# Altium schematic sheet V2.4分线板.SchDoc
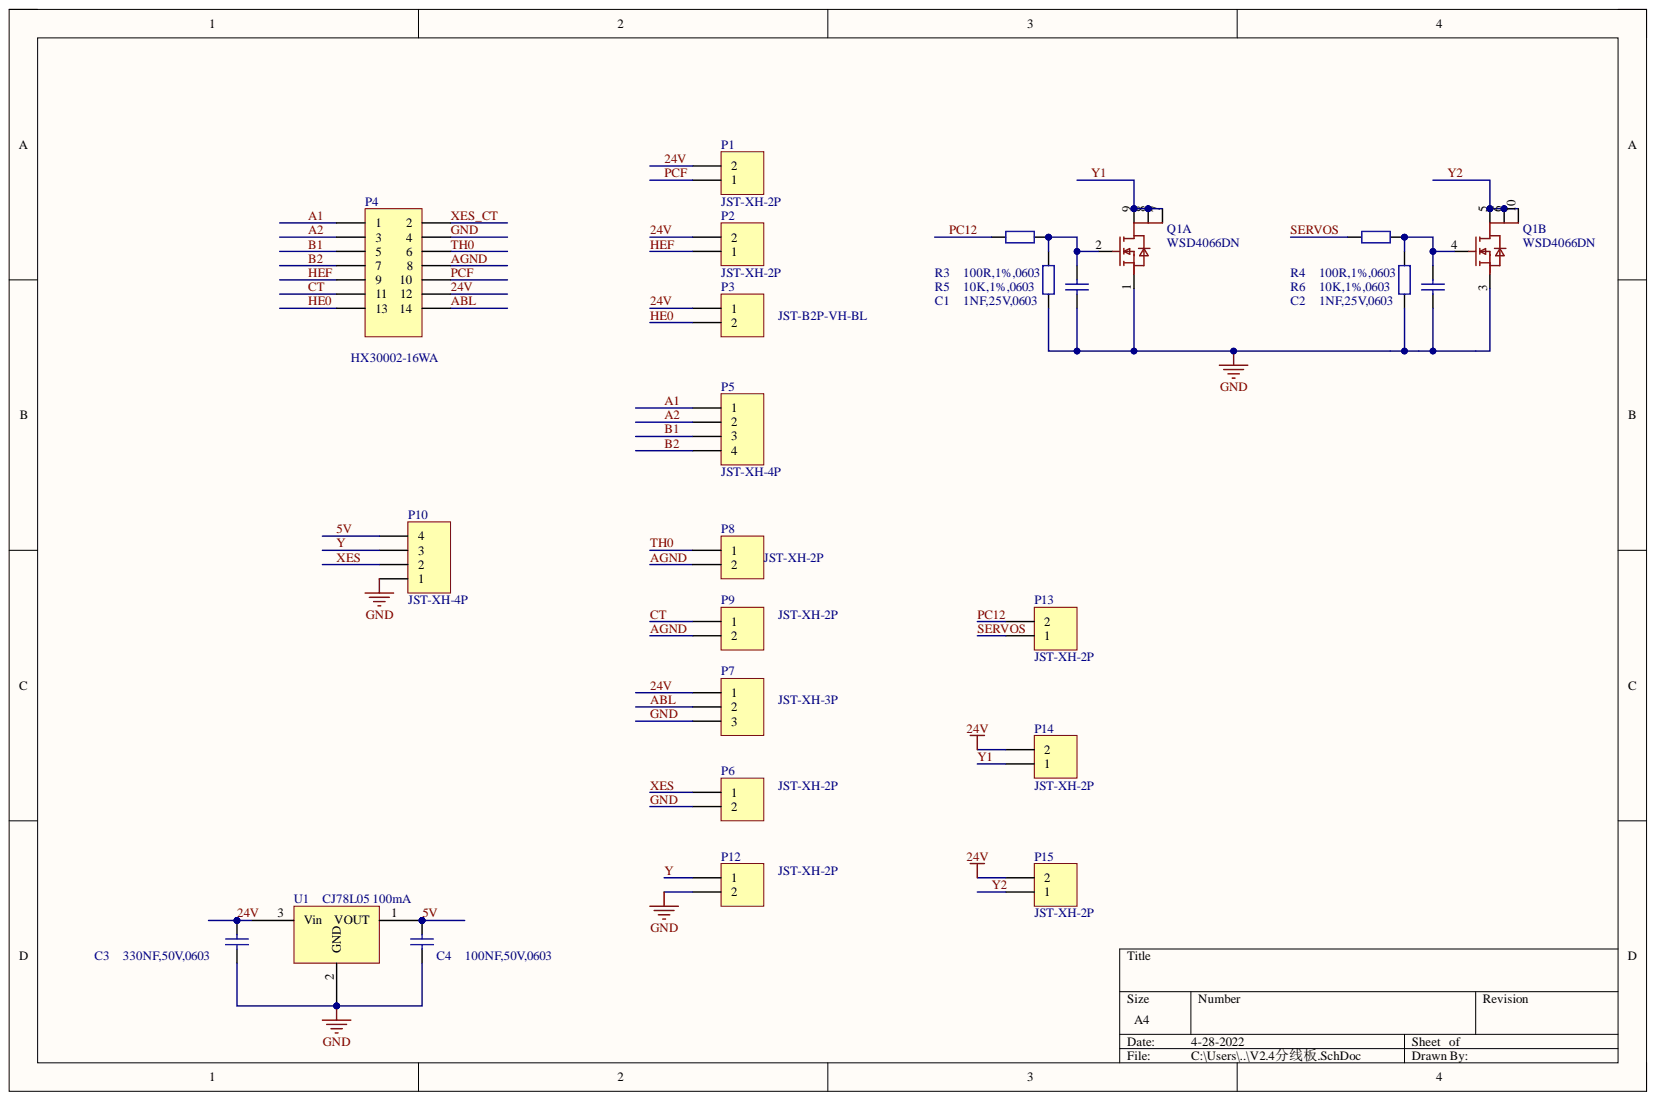
[diagram p0: V2.4分线板.SchDoc]

## Parts
C1  1NF,25V,0603  [0603_C]
C2  1NF,25V,0603  [0603_C]
C3  330NF,50V,0603  [0603_C]
C4  100NF,50V,0603  [0603_C]
P1  JST-XH-2P  [2.5mm-2p-JST]
P2  JST-XH-2P  [2.5mm-2p-JST]
P3  JST-B2P-VH-BL  [3.0-2P]
P4  HX30002-16WA  [3.0-2*7P]
P5  JST-XH-4P  [2.5mm-4p-JST]
P6  JST-XH-2P  [2.5mm-2p-JST]
P7  JST-XH-3P  [2.5mm-3p-JST]
P8  JST-XH-2P  [2.5mm-2p-JST]
P9  JST-XH-2P  [2.5mm-2p-JST]
P10  JST-XH-4P  [2.5mm-4p-JST]
P12  JST-XH-2P  [2.5mm-2p-JST]
P13  JST-XH-2P  [2.5mm-2p-JST]
P14  JST-XH-2P  [2.5mm-2p-JST]
P15  JST-XH-2P  [2.5mm-2p-JST]
Q1  WSD4066DN  [DFN-3X3-8]  (parts A,B)
R3  100R,1%,0603  [0603_R]
R4  100R,1%,0603  [0603_R]
R5  10K,1%,0603  [0603_R]
R6  10K,1%,0603  [0603_R]
U1  CJ78L05 100mA  [SOT-89(SOT-89-3)]

## Nets
5V: C4.2 P10.4 U1.1
24V: C3.2 P1.2 P2.2 P3.1 P4.12 P7.1 P14.2 P15.2 U1.3
A1: P4.1 P5.1
A2: P4.3 P5.2
ABL: P4.14 P7.2
AGND: P4.8 P8.2 P9.2
B1: P4.5 P5.3
B2: P4.7 P5.4
CT: P4.11 P9.1
GND: C1.1 C2.1 C3.1 C4.1 P4.4 P6.2 P7.3 P10.1 P12.2 Q1.1 Q1.3 R5.1 R6.1 U1.2
HE0: P3.2 P4.13
HEF: P2.1 P4.9
PC12: P13.2 R3.1
PCF: P1.1 P4.10
SERVOS: P13.1 R4.1
TH0: P4.6 P8.1
XES: P6.1 P10.2
XES_CT: P4.2
Y: P10.3 P12.1
Y1: P14.1 Q1.7 Q1.8 Q1.9
Y2: P15.1 Q1.5 Q1.6 Q1.10
NetC1_2: C1.2 Q1.2 R3.2 R5.2
NetC2_2: C2.2 Q1.4 R4.2 R6.2

Not connected: P4 15 16

## Library data of the parts
C1-C4 = Cap: Capacitor; Value: 100pF; Component Kind: Standard; LatestRevisionDate: 17-Jul-2002; LatestRevisionNote: Re-released for DXP Platform.; Library Name: Miscellaneous Devices.IntLib; PackageDescription: Radial Cap, Thru-Hole; 2 Leads; 0.3 in Pin Spacing; PackageReference: RAD-0.3; Published: 8-Jun-2000; Publisher: Altium Limited
P1 P6 P12-P15 = Header 2: FAN-A; Component Kind: Standard; LatestRevisionDate: 17-Jul-2002; LatestRevisionNote: Re-released for DXP Platform.; Library Name: Miscellaneous Connectors.IntLib; Signal Integrity: Connector; Publisher: Altium Limited
P2 P8 P9 = Header 2: FAN-B; Component Kind: Standard; LatestRevisionDate: 17-Jul-2002; LatestRevisionNote: Re-released for DXP Platform.; Library Name: Miscellaneous Connectors.IntLib; Signal Integrity: Connector; Publisher: Altium Limited
P3 = Header 2: 加热头; Component Kind: Standard; LatestRevisionDate: 17-Jul-2002; LatestRevisionNote: Re-released for DXP Platform.; Library Name: Miscellaneous Connectors.IntLib; Signal Integrity: Connector; Publisher: Altium Limited
P4 = Header 8X2: Header, 8-Pin, Dual row; Component Kind: Standard; LatestRevisionDate: 17-Jul-2002; LatestRevisionNote: Re-released for DXP Platform.; Library Name: Miscellaneous Connectors.IntLib; Signal Integrity: Connector; Publisher: Altium Limited
P5 P10 = Header 4: E-MOTOR; Component Kind: Standard; LatestRevisionDate: 17-Jul-2002; LatestRevisionNote: Re-released for DXP Platform.; Library Name: Miscellaneous Connectors.IntLib; Signal Integrity: Connector; Publisher: Altium Limited
P7 = Header 3: Header, 3-Pin; Component Kind: Standard; LatestRevisionDate: 17-Jul-2002; LatestRevisionNote: Re-released for DXP Platform.; Library Name: Miscellaneous Connectors.IntLib; Signal Integrity: Connector; Publisher: Altium Limited
R3-R6 = Res2: Resistor; Value: 1K; Component Kind: Standard; LatestRevisionDate: 17-Jul-2002; LatestRevisionNote: Re-released for DXP Platform.; Library Name: Miscellaneous Devices.IntLib; PackageDescription: Axial Device, Thru-Hole; 2 Leads; 0.4 in Pin Spacing; PackageReference: AXIAL-0.4; Published: 8-Jun-2000; Publisher: Altium Limited
U1 = CJ78L05: Component Kind: Standard; Library Name: LDO原理图库.SchLib
Q1 = WSD4066DN: Component Kind: Standard; Library Name: LDO原理图库.SchLib
